# Altium schematic sheet Page E_Micro_SD.SchDoc
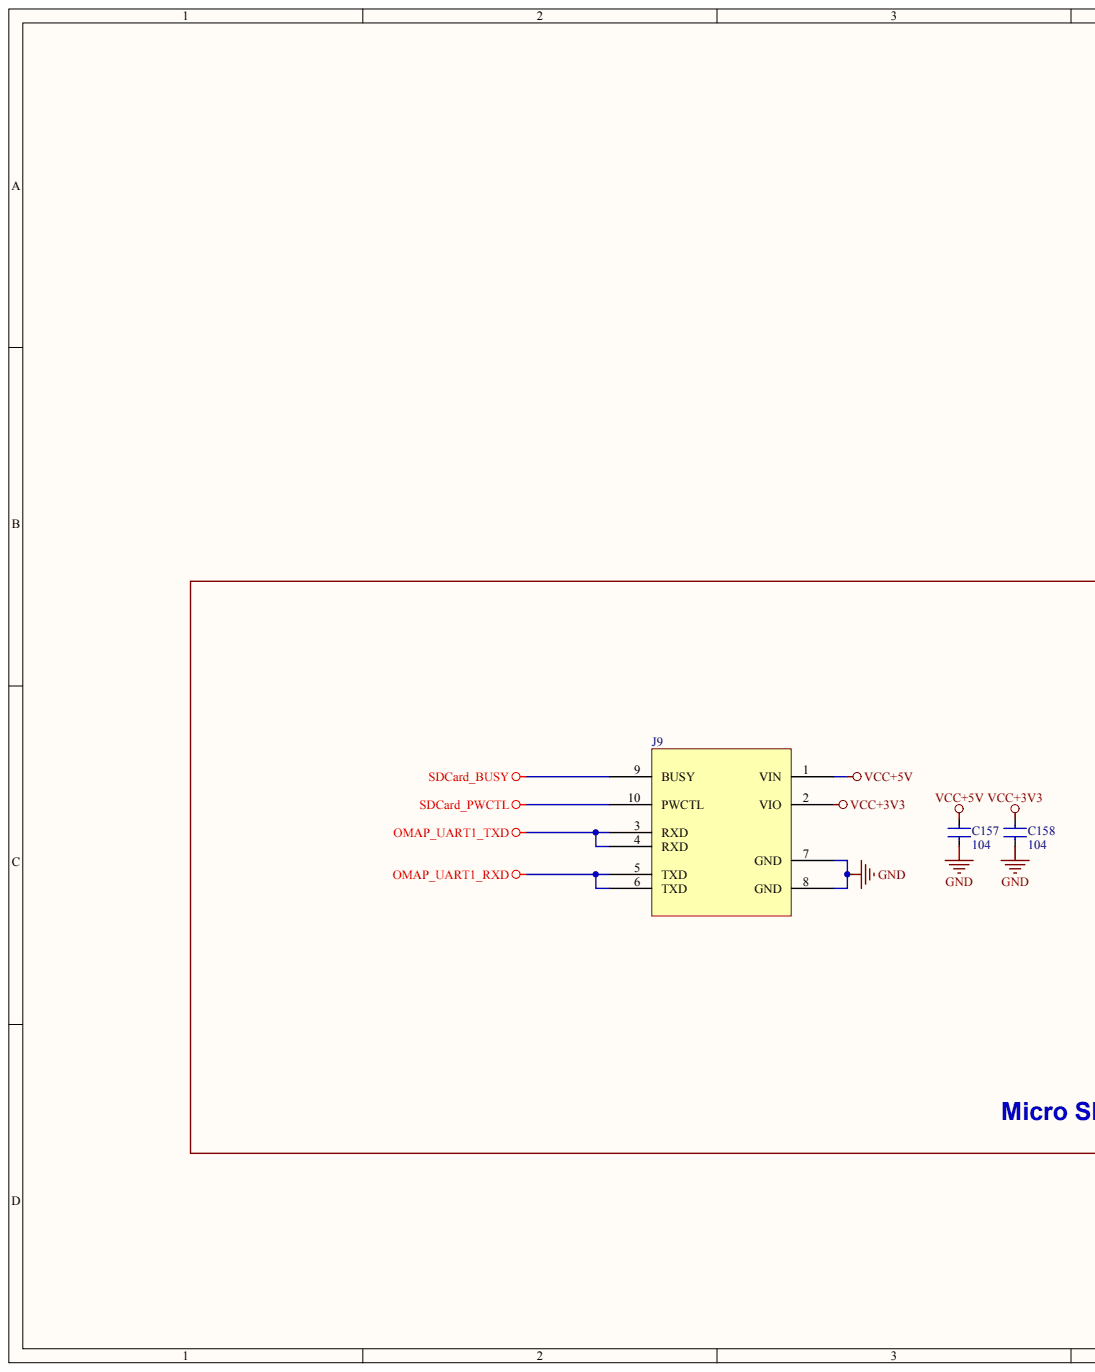
[diagram p0: Page E_Micro_SD.SchDoc, left]
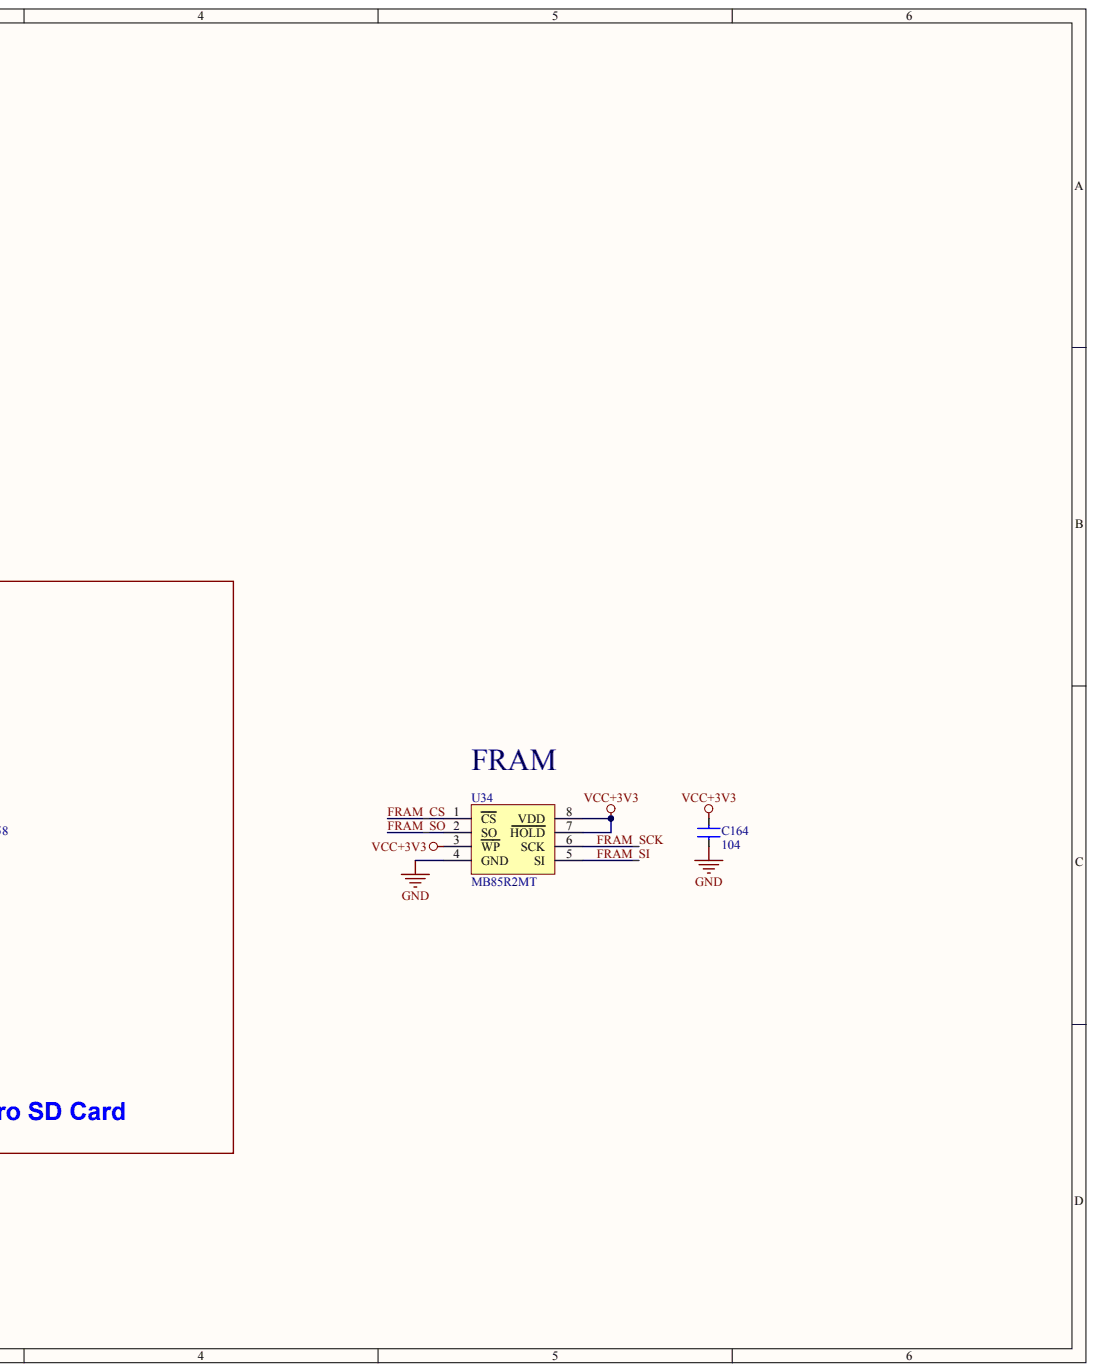
[diagram p1: Page E_Micro_SD.SchDoc, right]

## Parts
C157  104
C158  104
C164  104
J9  SDV600  (value not drawn)
U34  MB85R2MT

## Nets
GND: C157.1 C158.1 C164.1 J9.7(GND) J9.8(GND) U34.4(GND)
OMAP_UART1_RXD: J9.5(TXD) J9.6(TXD)
OMAP_UART1_TXD: J9.3(RXD) J9.4(RXD)
SDCARD_BUSY: J9.9(BUSY)
SDCARD_PWCTL: J9.10(PWCTL)
VCC+3V3: C158.2 C164.2 J9.2(VIO) U34.3(WP) U34.7(HOLD) U34.8(VDD)
VCC+5V: C157.2 J9.1(VIN)
FRAM_CS: U34.1(CS)
FRAM_SCK: U34.6(SCK)
FRAM_SI: U34.5(SI)
FRAM_SO: U34.2(SO)
